# Altium schematic sheet 03_IO-CONNECTION.SchDoc
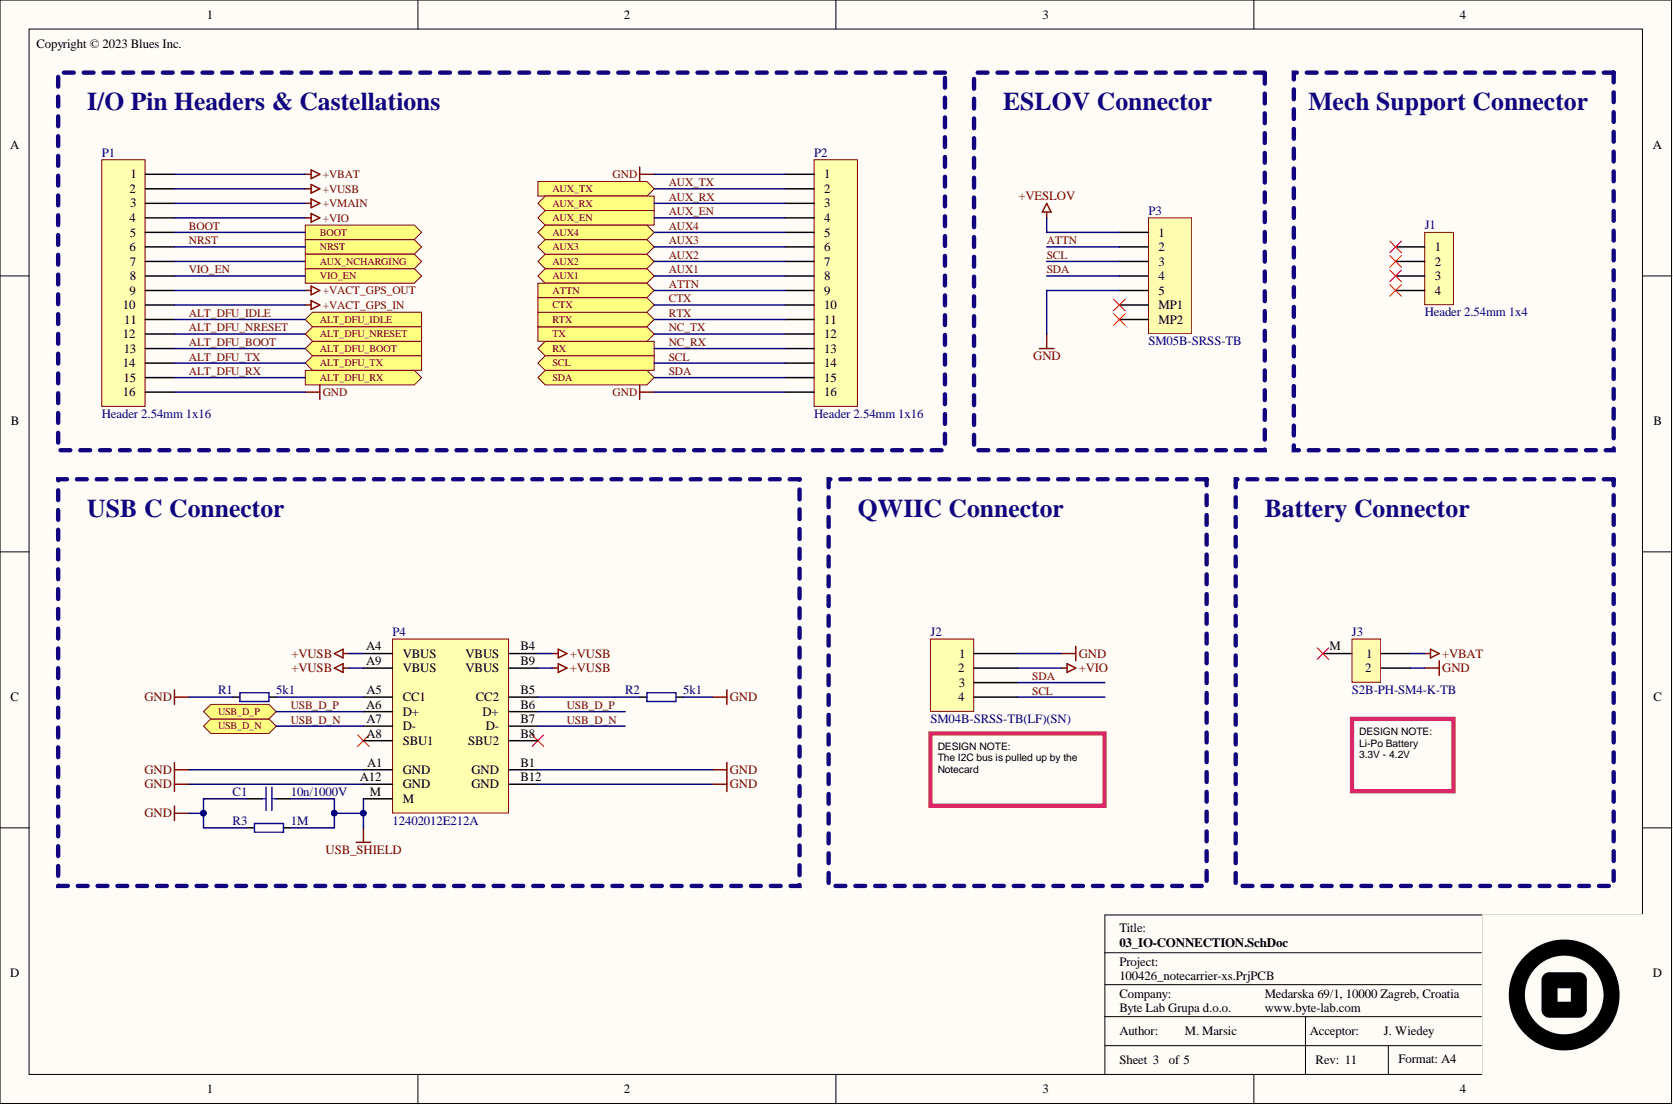
[diagram p0: 03_IO-CONNECTION.SchDoc]

## Parts
C1  10n/1000V  [C-1206]
J1  Header 2.54mm 1x4  [Header 2.54mm 1X4]
J2  SM04B-SRSS-TB(LF)(SN)  [JST SM04B-SRSS-TB]
J3  S2B-PH-SM4-K-TB  [Conn S2B-PH-SM4]
P1  Header 2.54mm 1x16  [Header 2.54mm 1X16]
P2  Header 2.54mm 1x16  [Header 2.54mm 1X16]
P3  SM05B-SRSS-TB  [Conn_SM05B-SRSS-TB]
P4  12402012E212A  [CONN_12402012E212A]
R1  5k1  [R-0402]
R2  5k1  [R-0402]
R3  1M  [R-1206]

## Ports
ALT_DFU_BOOT, ALT_DFU_IDLE, ALT_DFU_NRESET, ALT_DFU_RX, ALT_DFU_TX, ATTN, AUX1, AUX2, AUX3, AUX4, AUX_EN, AUX_NCHARGING, AUX_RX, AUX_TX, BOOT, CTX, NRST, RTX, RX, SCL, SDA, TX, USB_D_N, USB_D_P, VIO_EN

## Nets
+VACT_GPS_IN: P1.10
+VACT_GPS_OUT: P1.9
+VBAT: J3.1 P1.1
+VESLOV: P3.1
+VIO: J2.2 P1.4
+VMAIN: P1.3
+VUSB: P1.2 P4.A4(VBUS) P4.A9(VBUS) P4.B4(VBUS) P4.B9(VBUS)
GND: C1.2 J2.1 J3.2 P1.16 P2.1 P2.16 P3.5 P4.A1(GND) P4.A12(GND) P4.B1(GND) P4.B12(GND) R1.1 R2.2 R3.2
USB_SHIELD: C1.1 P4.M R3.1
ALT_DFU_BOOT: P1.13 ; port ALT_DFU_BOOT
ALT_DFU_IDLE: P1.11 ; port ALT_DFU_IDLE
ALT_DFU_NRESET: P1.12 ; port ALT_DFU_NRESET
ALT_DFU_RX: P1.15 ; port ALT_DFU_RX
ALT_DFU_TX: P1.14 ; port ALT_DFU_TX
ATTN: P2.9 P3.2 ; port ATTN
AUX1: P2.8 ; port AUX1
AUX2: P2.7 ; port AUX2
AUX3: P2.6 ; port AUX3
AUX4: P2.5 ; port AUX4
AUX_EN: P2.4 ; port AUX_EN
AUX_NCHARGING: P1.7 ; port AUX_NCHARGING
AUX_RX: P2.3 ; port AUX_RX
AUX_TX: P2.2 ; port AUX_TX
BOOT: P1.5 ; port BOOT
CTX: P2.10 ; port CTX
NC_RX: P2.13 ; port RX
NC_TX: P2.12 ; port TX
NRST: P1.6 ; port NRST
RTX: P2.11 ; port RTX
SCL: J2.4 P2.14 P3.3 ; port SCL
SDA: J2.3 P2.15 P3.4 ; port SDA
USB_D_N: P4.A7(D-) P4.B7(D-) ; port USB_D_N
USB_D_P: P4.A6(D+) P4.B6(D+) ; port USB_D_P
VIO_EN: P1.8 ; port VIO_EN
NetP4_A5: P4.A5(CC1) R1.2
NetP4_B5: P4.B5(CC2) R2.1

Not connected: J1 1 2 3 4; J3 M; P3 MP1 MP2; P4 A8(SBU1) B8(SBU2)

## Library data of the parts
C1 = C1206-10n-1000V-X7R - CS1206KKX7RCBB103: Capacitor ceramic 10n ±10% X7R 1000V 1206; BL Part Number: 2007-449; Manufacturer: YAGEO; Checked_By: Marsic; Drawn_By: Hamin; Component Kind: Standard; DateModified: 03-05-2023; Library Name: BL_Passive.SchLib; Manufacturer Part Number: CS1206KKX7RCBB103; Supplier 1: Digi-Key; Supplier Part Number 1: 311-3578-1-ND
J1 = Conn Header 2.54mm 1X4: 2.54mm pitch 4 pin (1x4) top entry wire to board connector THT; BL Part Number: 2001-524; Checked_By: Dodig; Drawn_By: Puskar; Component Kind: Standard; DateModified: 29-01-2024; Library Name: BL_Mechanical.SchLib; Manufacturer: N/A; Manufacturer Part Number: N/A
J2 = SM04B-SRSS-TB(LF)(SN): CONN HEADER SH 4POS SIDE 1MM TIN; BL Part Number: 2002-058; Manufacturer: JST Sales America Inc.; Drawn_By: Blues Wireless; Component Kind: Standard; Library Name: n21-p2.SchLib; Manufacturer Part Number: SM04B-SRSS-TB(LF)(SN); Supplier 1: Digi-Key; Supplier Part Number 1: 455-1804-1-ND
J3 = Conn S2B-PH-SM4-K-TB: 2.00mm pitch 2 pin side entry wire to board connector, SMD; BL Part Number: 2007-662; Manufacturer: JST; Manufacturer Part Number: S2B-PH-SM4-K-TB(LF)(SN); Drawn_By: Hamin; Component Kind: Standard; DateModified: 19-06-2023; Library Name: BL_Mechanical.SchLib; Supplier 1: Digi-Key
P1 P2 = Conn Header 2.54mm 1X16: 2.54mm pitch 16 pin (1x16) top entry wire to board connector THT; BL Part Number: 2001-478; Checked_By: Hamin; Drawn_By: Puskar; Component Kind: Standard; DateModified: 07-12-2013; Library Name: BL_Mechanical.SchLib; Manufacturer: N/A; Manufacturer Part Number: N/A
P3 = Conn SM05B-SRSS-TB: 1mm pitch 5 pin side entry wire to board connector, SMD; BL Part Number: 2008-432; Manufacturer: JST; Checked_By: Hlupic; Drawn_By: Anic-Ivicic; Component Kind: Standard; DateModified: 18-01-2024; Library Name: BL_Mechanical.SchLib; Manufacturer Part Number: SM05B-SRSS-TB; Supplier 1: Digi-Key; Supplier Part Number 1: 455-SM05B-SRSS-TBCT-ND
P4 = Conn USB C 12402012E212A: CONN RCP USB2.0 TYP C 24P SMD RA; BL Part Number: 2008-437; Manufacturer: Amphenol; Checked_By: Hlupic; Drawn_By: Anic-Ivicic; Component Kind: Standard; DateModified: 19-03-2024; Library Name: BL_Mechanical.SchLib; Manufacturer Part Number: 12402012E212A; Supplier 1: Digi-Key; Supplier Part Number 1: 664-12402012E212ATR-ND
R1 R2 = R0402-5k1-1: Resistor 5k1 ±1% 0402; BL Part Number: 2004-098; Checked_By: Hamin; Drawn_By: PuskarJ; Component Kind: Standard; DateModified: 21-09-2018; Library Name: BL_Passive.SchLib; Manufacturer: N/A; Manufacturer Part Number: N/A; Supplier 1: Digi-Key; Supplier Part Number 1: P5.10KLCT-ND
R3 = R1206-1M-1: Resistor 1M ±1% 1206; BL Part Number: 2001-438; Checked_By: Golubic; Drawn_By: Zvonc; Component Kind: Standard; DateModified: 01-07-2014; Library Name: BL_Passive.SchLib; Manufacturer: N/A; Manufacturer Part Number: N/A
